# Altium schematic sheet MIPI_CSI.SchDoc(MIPI_CSI)
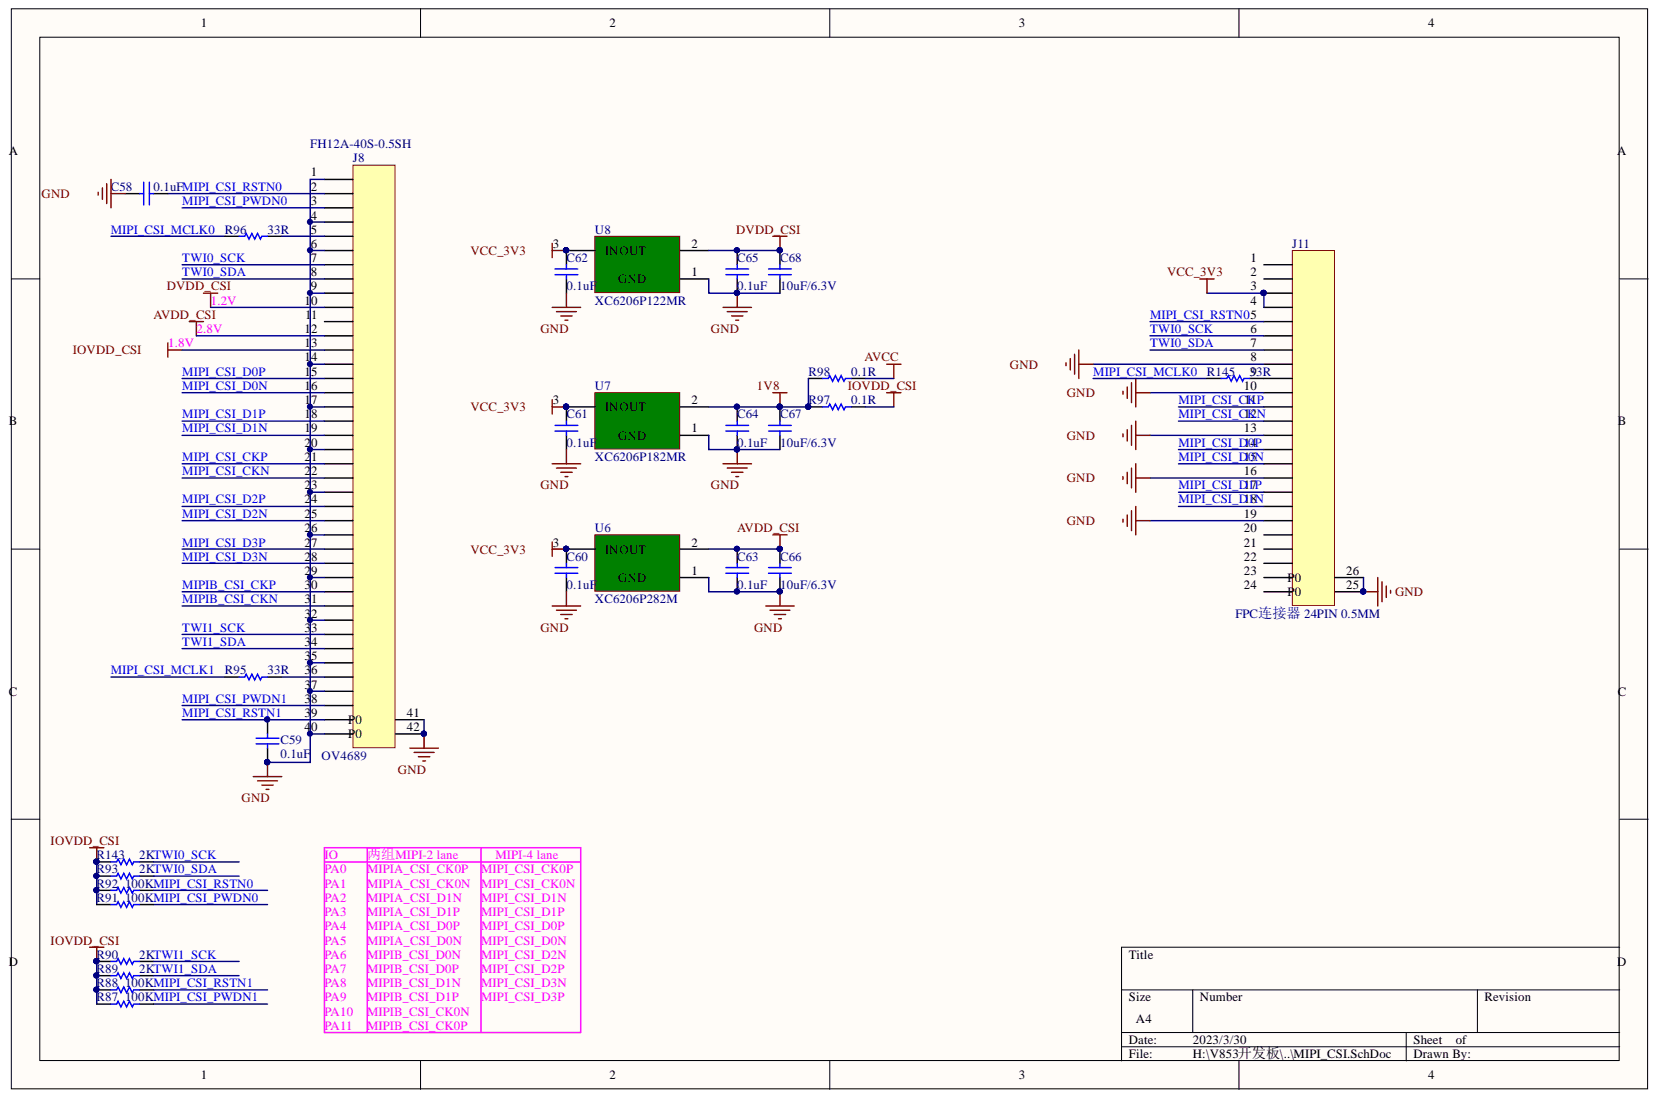
[diagram p0: MIPI_CSI.SchDoc]

## Parts
C58  0.1uF  [0402]
C59  0.1uF  [0402]
C60  0.1uF  [0402]
C61  0.1uF  [0402]
C62  0.1uF  [0402]
C63  0.1uF  [0402]
C64  0.1uF  [0402]
C65  0.1uF  [0402]
C66  10uF/6.3V  [0402]
C67  10uF/6.3V  [0402]
C68  10uF/6.3V  [0402]
J8  FH12A-40S-0.5SH  value OV4689  [FPC-SMD_40P-P0.50_FG-H2.0-40P]
J11  FPC连接器 24PIN 0.5MM  [FPC-SMD_FPC-0.5MM-24P-MIRROR]
R87  100K  [0402]
R88  100K  [0402]
R89  2K  [0402]
R90  2K  [0402]
R91  100K  [0402]
R92  100K  [0402]
R93  2K  [0402]
R95  33R  [0402]
R96  33R  [0402]
R97  0.1R  [0402]
R98  0.1R  [0402]
R143  2K  [0402]
R145  33R  [0402]
U6  XC6206P282M  [SOT23]
U7  XC6206P182MR  [SOT23]
U8  XC6206P122MR  [SOT23]

## Nets
1V8: C64.1 C67.1 R97.2 R98.2 U7.2
AVCC: R98.1
AVDD_CSI: C63.1 C66.1 J8.12 U6.2
DVDD_CSI: C65.1 C68.1 J8.10 U8.2
GND: C58.2 C59.2 C60.2 C61.2 C62.2 C63.2 C64.2 C65.2 C66.2 C67.2 C68.2 J8.1 J8.4 J8.6 J8.9 J8.14 J8.17 J8.20 J8.23 J8.26 J8.29 J8.32 J8.35 J8.37 J8.40 J8.41 J8.42 J11.8 J11.10 J11.13 J11.16 J11.19 J11.25 J11.26 U6.1 U7.1 U8.1
IOVDD_CSI: J8.13 R87.2 R88.2 R89.2 R90.2 R91.2 R92.2 R93.2 R97.1 R143.2
VCC_3V3: C60.1 C61.1 C62.1 J11.3 J11.4 U6.3 U7.3 U8.3
MIPI_CSI_CKN: J8.22 J11.12
MIPI_CSI_CKP: J8.21 J11.11
MIPI_CSI_D0N: J8.16 J11.15
MIPI_CSI_D0P: J8.15 J11.14
MIPI_CSI_D1N: J8.19 J11.18
MIPI_CSI_D1P: J8.18 J11.17
MIPI_CSI_D2N: J8.25
MIPI_CSI_D2P: J8.24
MIPI_CSI_D3N: J8.28
MIPI_CSI_D3P: J8.27
MIPI_CSI_MCLK0: R96.2 R145.2
MIPI_CSI_MCLK1: R95.2
MIPI_CSI_PWDN0: J8.3 R91.1
MIPI_CSI_PWDN1: J8.38 R87.1
MIPI_CSI_RSTN0: C58.1 J8.2 J11.5 R92.1
MIPI_CSI_RSTN1: C59.1 J8.39 R88.1
MIPIB_CSI_CKN: J8.31
MIPIB_CSI_CKP: J8.30
TWI0_SCK: J8.7 J11.6 R143.1
TWI0_SDA: J8.8 J11.7 R93.1
TWI1_SCK: J8.33 R90.1
TWI1_SDA: J8.34 R89.1
NetJ8_5: J8.5 R96.1
NetJ8_36: J8.36 R95.1
NetJ11_9: J11.9 R145.1

Not connected: J8 11; J11 1 2 20 21 22 23 24

## Library data of the parts
C58-C68 = Cap: Capacitor; PartNumber: CAP100NF; Component Kind: Standard; LatestRevisionDate: 17-Jul-2002; LatestRevisionNote: Re-released for DXP Platform.; Library Name: Miscellaneous Devices.IntLib; PackageReference: 0402; Published: 8-Jun-2000; Signal Integrity: Cap; Simulation: CAP; Publisher: Altium Limited
  C58-C65: Value: 0.1uF
  C66-C68: Value: 10uF/6.3V
J8 = FPC40P: Component Kind: Standard; Library Name: HM2.SchLib
J11 = FPC24P: Component Kind: Standard; JLC NO: C9159; Library Name: HM2.SchLib
R87-R93 R95-R98 R143 R145 = Res1: Resistor; PartNumber: RES2.2K; Component Kind: Standard; LatestRevisionDate: 17-Jul-2002; LatestRevisionNote: Re-released for DXP Platform.; Library Name: Miscellaneous Devices.IntLib; PackageReference: 0402; Published: 8-Jun-2000; Signal Integrity: Res; Simulation: RESISTOR; Publisher: Altium Limited
  R87 R88 R91 R92: Value: 100K
  R89 R90 R93 R143: Value: 2K
  R95 R96 R145: Value: 33R
  R97 R98: Value: 0.1R
U6-U8 = XC6206: Component Kind: Standard; Library Name: LIB_MANGO.IntLib
  U7: JLC NO: C2909966
  U8: JLC NO: C2827649
